# Altium schematic sheet SC2150P_EngEVM.SchDoc
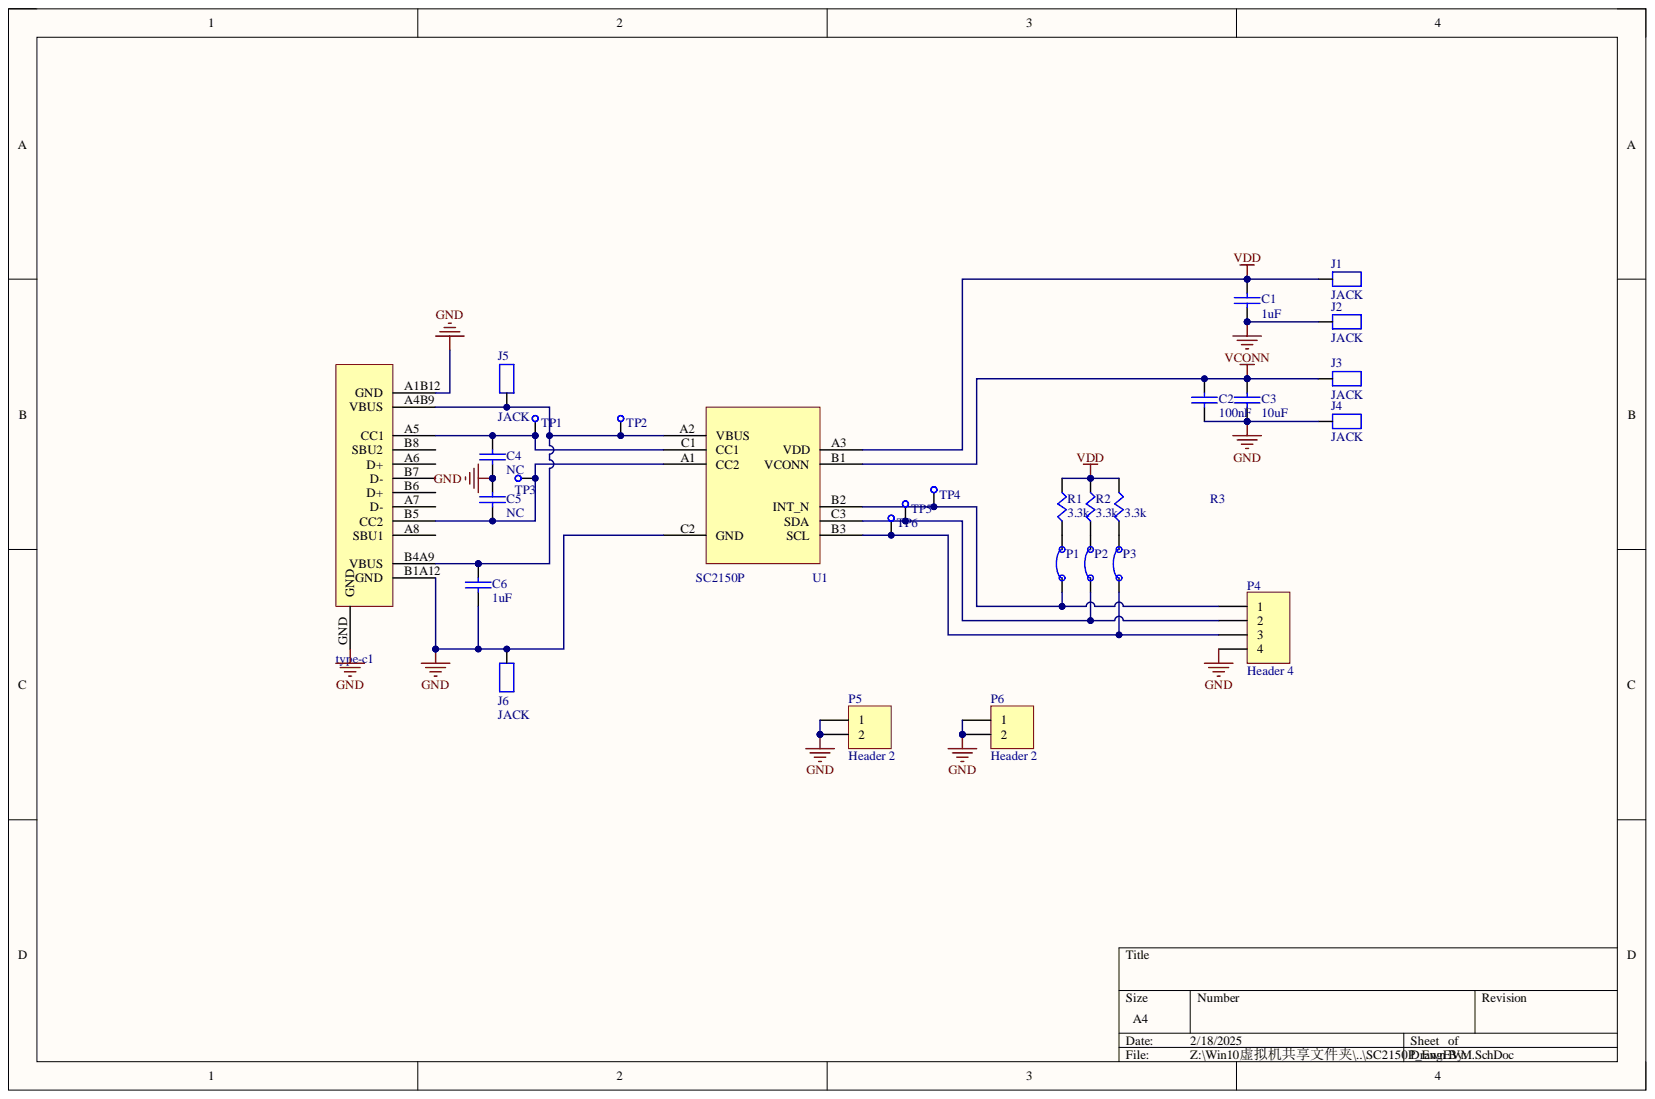
[diagram p0: SC2150P_EngEVM.SchDoc]

## Parts
C1  1uF  [0603-C]
C2  100nF  [0603-C]
C3  10uF  [0603-C]
C4  NC  [0603-C]
C5  NC  [0603-C]
C6  1uF  [0603-C]
J1  JACK  [PAD3MM]
J2  JACK  [PAD3MM]
J3  JACK  [PAD3MM]
J4  JACK  [PAD3MM]
J5  JACK  [PAD3MM]
J6  JACK  [PAD3MM]
P1  SHORT_ON  [HDR1X2]  (value not drawn)
P2  SHORT_ON  [HDR1X2]  (value not drawn)
P3  SHORT_ON  [HDR1X2]  (value not drawn)
P4  Header 4  [HDR1X4]
P5  Header 2  [HDR1X2]
P6  Header 2  [HDR1X2]
R1  3.3k  [0603-R]
R2  3.3k  [0603-R]
R3  3.3k  [0603-R]
TP1  TP  [SIP-2.54-1]
TP2  TP  [SIP-2.54-1]
TP3  TP  [SIP-2.54-1]
TP4  TP  [SIP-2.54-1]
TP5  TP  [SIP-2.54-1]
TP6  TP  [SIP-2.54-1]
type-c1  TYPE-C  [type-c]  (value not drawn)
U1  SC2150P  [LGA9C40P3X3_138X134X55]

## Nets
GND: C1.2 C2.1 C3.1 C4.1 C5.2 C6.1 J2.1 J4.1 J6.1 P4.4 P5.1 P5.2 P6.1 P6.2 type-c1.A1B12(GND) type-c1.B1A12(GND) type-c1.GND U1.C2(GND)
VCONN: C2.2 C3.2 J3.1 U1.B1(VCONN)
VDD: C1.1 J1.1 R1.1 R2.1 R3.1 U1.A3(VDD)
NetC4_2: C4.2 TP1.1 type-c1.A5(CC1) U1.C1(CC1)
NetC5_1: C5.1 TP3.1 type-c1.B5(CC2) U1.A1(CC2)
NetC6_2: C6.2 J5.1 TP2.1 type-c1.A4B9(VBUS) type-c1.B4A9(VBUS) U1.A2(VBUS)
NetP1_1: P1.1 P4.1 TP4.1 U1.B2(INT_N)
NetP1_2: P1.2 R1.2
NetP2_1: P2.1 P4.2 TP5.1 U1.C3(SDA)
NetP2_2: P2.2 R2.2
NetP3_1: P3.1 P4.3 TP6.1 U1.B3(SCL)
NetP3_2: P3.2 R3.2

Not connected: type-c1 A6(D+) A7(D-) A8(SBU1) B6(D+) B7(D-) B8(SBU2)

## Library data of the parts
C1-C6 = CAP: Capacitor; Component Kind: Standard; Library Name: Backup of SC_ACDC_AD_libsch.Lib
P1-P3 = JUMP: Component Kind: Standard; Library Name: Backup of SC_ACDC_AD_libsch.Lib
P4 = Header 4: Header, 4-Pin; Component Kind: Standard; LatestRevisionDate: 17-Jul-2002; LatestRevisionNote: Re-released for DXP Platform.; Library Name: Miscellaneous Connectors.IntLib; Signal Integrity: Connector; Publisher: Altium Limited
P5 P6 = Header 2: Header, 2-Pin; Component Kind: Standard; LatestRevisionDate: 17-Jul-2002; LatestRevisionNote: Re-released for DXP Platform.; Library Name: Miscellaneous Connectors.IntLib; Signal Integrity: Connector; Publisher: Altium Limited
R1-R3 = RES2: Component Kind: Standard; Library Name: Backup of SC_ACDC_AD_libsch.Lib
TP1-TP6 = TP: test point; Component Kind: Standard; Library Name: user.SchLib
type-c1 = type-c1: Component Kind: Standard; Library Name: Backup of SC_ACDC_AD_libsch.Lib
U1 = SC2150A: Component Kind: Standard
J1-J6 = JACK: Component Kind: Standard; Description: Jack; Library Name: wolverine_for_vooc.SCHLIB
